# Altium schematic sheet ignition-adapter.SchDoc
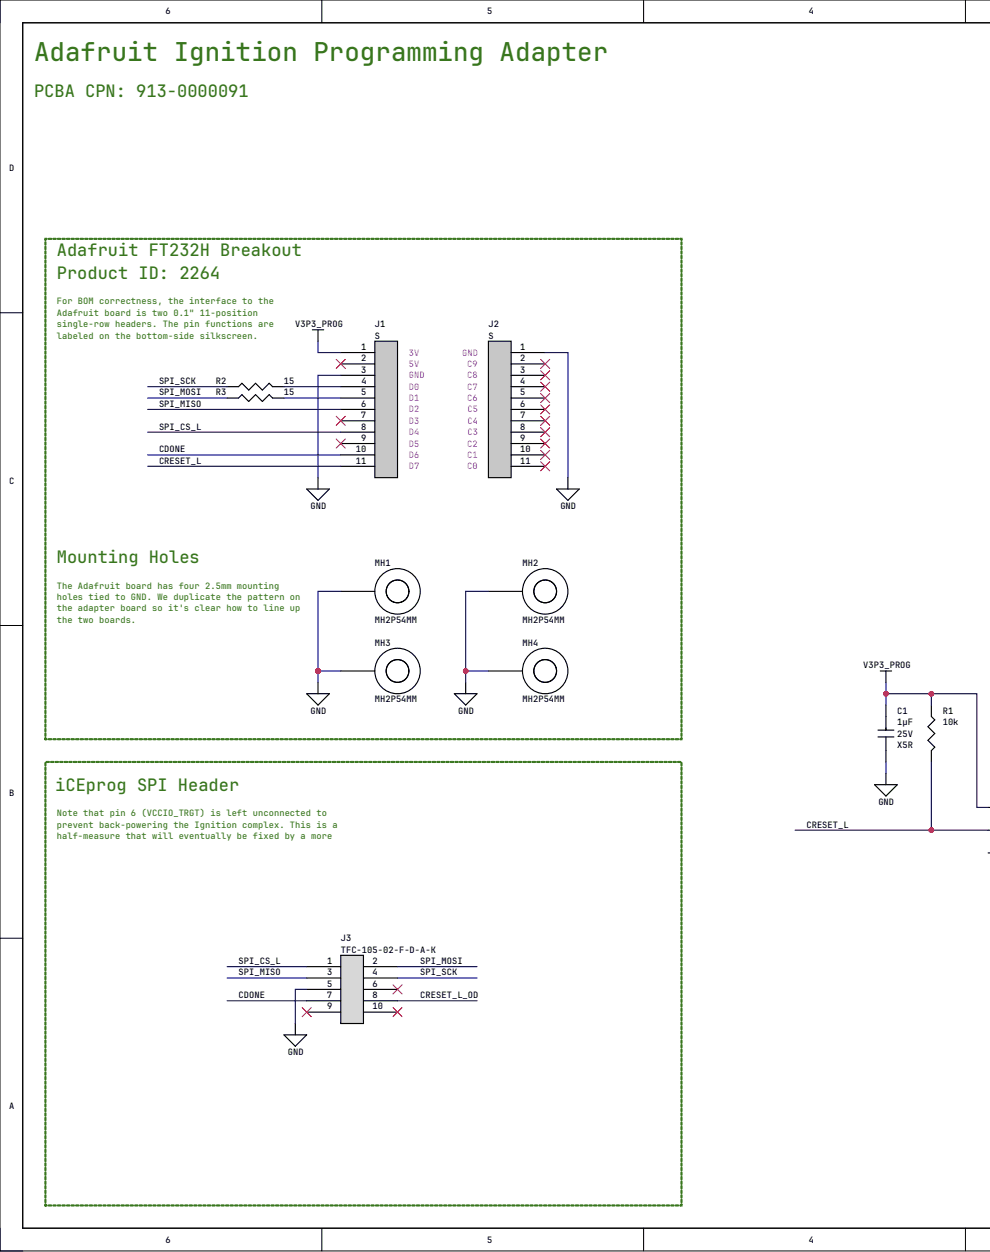
[diagram p0: ignition-adapter.SchDoc, left]
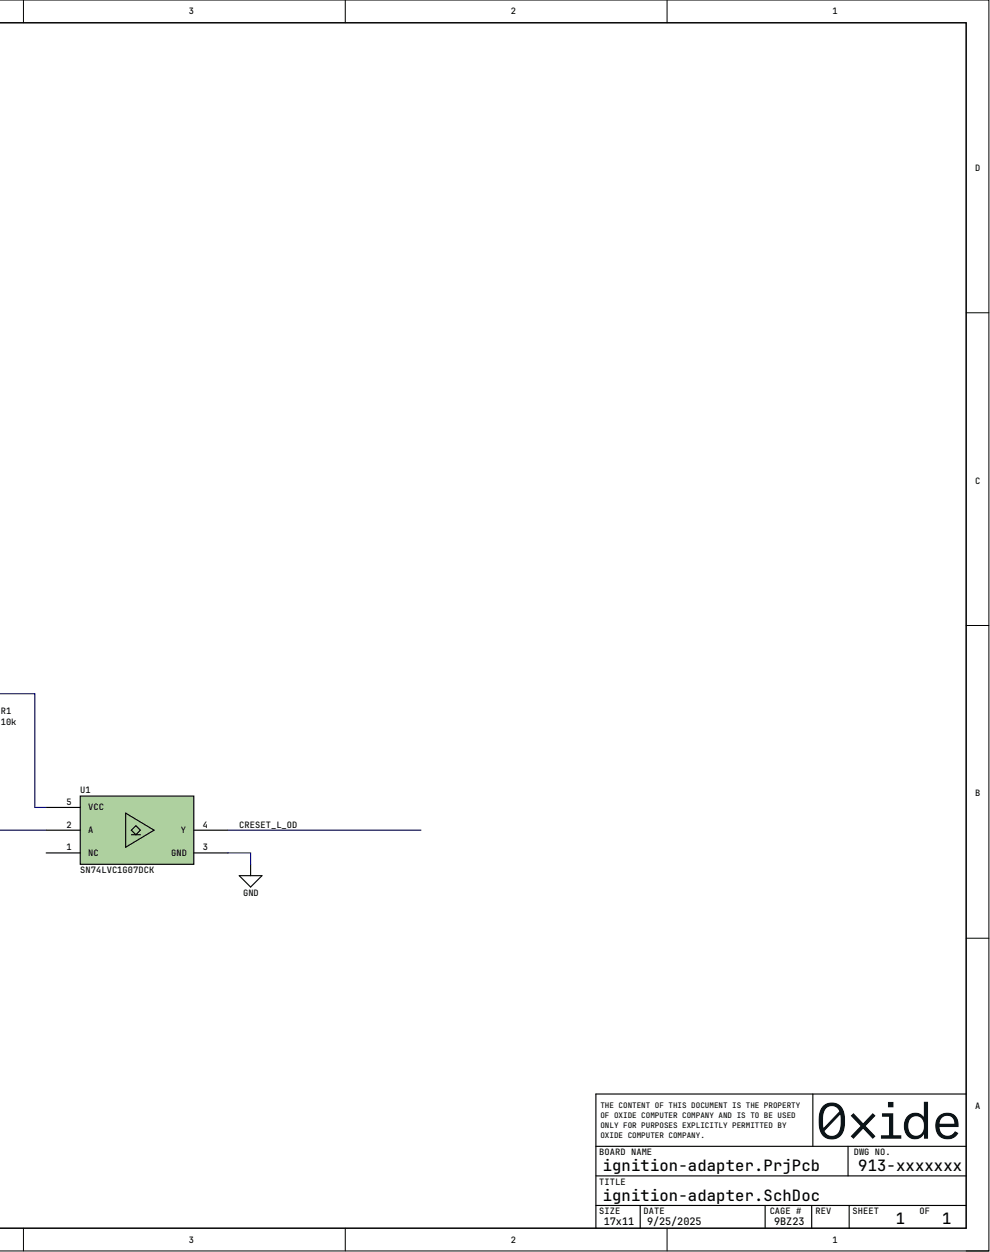
[diagram p1: ignition-adapter.SchDoc, right]

## Parts
C1  value 1µF  Dielectric Class: X5R  Voltage: 25V  [CAPC1005X06N]  (hidden comment: GRM155R61E105KE11J)
J1  S  [0022284110-Footprint-1]
J2  S  [0022284110-Footprint-1]
J3  TFC-105-02-F-D-A-K  [SAMTEC_TFC-105-02-F-D-A-K]
MH1  MH2P54MM  [MH2P54MM-Footprint-1]
MH2  MH2P54MM  [MH2P54MM-Footprint-1]
MH3  MH2P54MM  [MH2P54MM-Footprint-1]
MH4  MH2P54MM  [MH2P54MM-Footprint-1]
R1  value 10k  [RESC1005X04N]  (hidden comment: RES 10K Ohm 1.0% 0.0625W 0402)
R2  value 15  [RESC1005X04N]  (hidden comment: RES 15R Ohm 1.0% 0.0625W 0402)
R3  value 15  [RESC1005X04N]  (hidden comment: RES 15R Ohm 1.0% 0.0625W 0402)
U1  SN74LVC1G07DCK  [TI_DCK0005A]

## Nets
GND: C1.2 J1.3 J2.1 J3.5 MH1.MH MH2.MH MH3.MH MH4.MH U1.3(GND)
V3P3_PROG: C1.1 J1.1 R1.1 U1.5(VCC)
CDONE: J1.10 J3.7
CRESET_L: J1.11 R1.2 U1.2(A)
CRESET_L_OD: J3.8 U1.4(Y)
SPI_CS_L: J1.8 J3.1
SPI_MISO: J1.6 J3.3
SPI_MOSI: J3.2 R3.1
SPI_SCK: J3.4 R2.1
NetJ1_4: J1.4 R2.2
NetJ1_5: J1.5 R3.2

Not connected: J1 2 7 9; J2 2 3 4 5 6 7 8 9 10 11; J3 6 9 10; U1 1(NC)

## Library data of the parts
C1 = CMP-00433-28: CAP MLCC 1µF ±10% 25V X5R 0402 0.020in; Manufacturer: Murata; CPN: 212-0000173; Kind: Ceramic; max_temp: 85; ruc: Standard; Component Kind: Standard; datasheet: https://drive.google.com/file/d/124opgVhcHugMcoRgv9tywCJIUFcP1P69/view?usp=sharing; height: 0.6; Manufacturer PN: GRM155R61E105KE11J; Package: 0402; plm_link: https://mfg.duro.app/component/view/60ecaca7cfd44e00083bd5a9; Tolerance: 10%; Library Name: Oxide Computer Company
J1 J2 = CMP-002-00109-2: Connector Header Through Hole 11 position 0.100" (2.54mm); Manufacturer: Molex; Manufacturer PN: 0022284110; CPN: 215-0000195; Component Kind: Standard; Library Name: Oxide Computer Company
J3 = CMP-002-00009-21: .050 X .050 TERMINAL STRIP; Manufacturer: Samtec; contact_plating: Gold; contact_type: Pin; CPN: 215-0000068; current_rating: 1.7; keyed: True; mount_direction: vertical; mount_style: Smt; num_contacts: 10; num_rows: 2; pin_pitch: 1.27; plating_thickness: 0.0762; retention_mech: None; ruc: Standard; trade_name: TFC; voltage_rating: 219; Component Kind: Standard; datasheet: https://drive.google.com/file/d/1PNZOtTlwjR_iZnEbDkBQg4SdCrA19alR/view?usp=sharing; height: 5.59; Manufacturer PN: TFC-105-02-F-D-A-K; plm_link: https://mfg.duro.app/component/view/6116e6d93ae8d00007eba0fb; Library Name: Oxide Computer Company
MH1-MH4 = CMP-00028-00008-1: 2.54mm PTH mounting hole for Ignition programming adapter board, to match Adafruit product 2264; Component Kind: Standard; Library Name: Oxide Computer Company
R1 = CMP-009-02109-22: CPN: 232-0000125; mount_style: Smt; Power: 62.5mW; ruc: Standard; temp_co: 100; Tolerance: 1%; Voltage Rating: 50V; voltage_rating: 50; Case/Package: 0402; Component Kind: Standard; Description: RES 10K Ohm 1.0% 0.0625W 0402; height: 0.4; Mounting Technology: ThickFilm; Package: 0402; plm_link: https://mfg.duro.app/component/view/6116e6cf419c1f0008e909cd; technology: ThickFilm; Library Name: Oxide Computer Company
R2 R3 = CMP-009-02654-23: CPN: 232-0001345; mount_style: Smt; Power: 62.5mW; ruc: Standard; temp_co: 100; Tolerance: 1%; Voltage Rating: 50V; voltage_rating: 50; Case/Package: 0402; Component Kind: Standard; Description: RES 15R Ohm 1.0% 0.0625W 0402; height: 0.4; Mounting Technology: ThickFilm; Package: 0402; plm_link: https://mfg.duro.app/component/view/64c2cb89cdd7cc00086473d8; technology: ThickFilm; Library Name: Oxide Computer Company
U1 = CMP-031-00250-7: Buffer, Non-Inverting 1 Element 1 Bit per Element Open Drain Output SC-70-5; Manufacturer: Texas Instruments; Manufacturer PN: SN74LVC1G07DCKR; CPN: 221-0000199; max_temp: 125; min_temp: -40; pitch: 0.65; ruc: Standard; voltage: 1.65-5.5; Component Kind: Standard; datasheet: https://drive.google.com/file/d/1bIZDR_QQu0wFV7Qqf2eSD1MJTg4tsIyE/view?usp=drive_link; height: 1.1; length: 2.15; Package: SC-70-5; plm_link: https://mfg.duro.app/component/view/660417f05ccfcb0008afc187; width: 2.4; Library Name: Oxide Computer Company
